# Altium schematic sheet lv_signal_temp.SchDoc
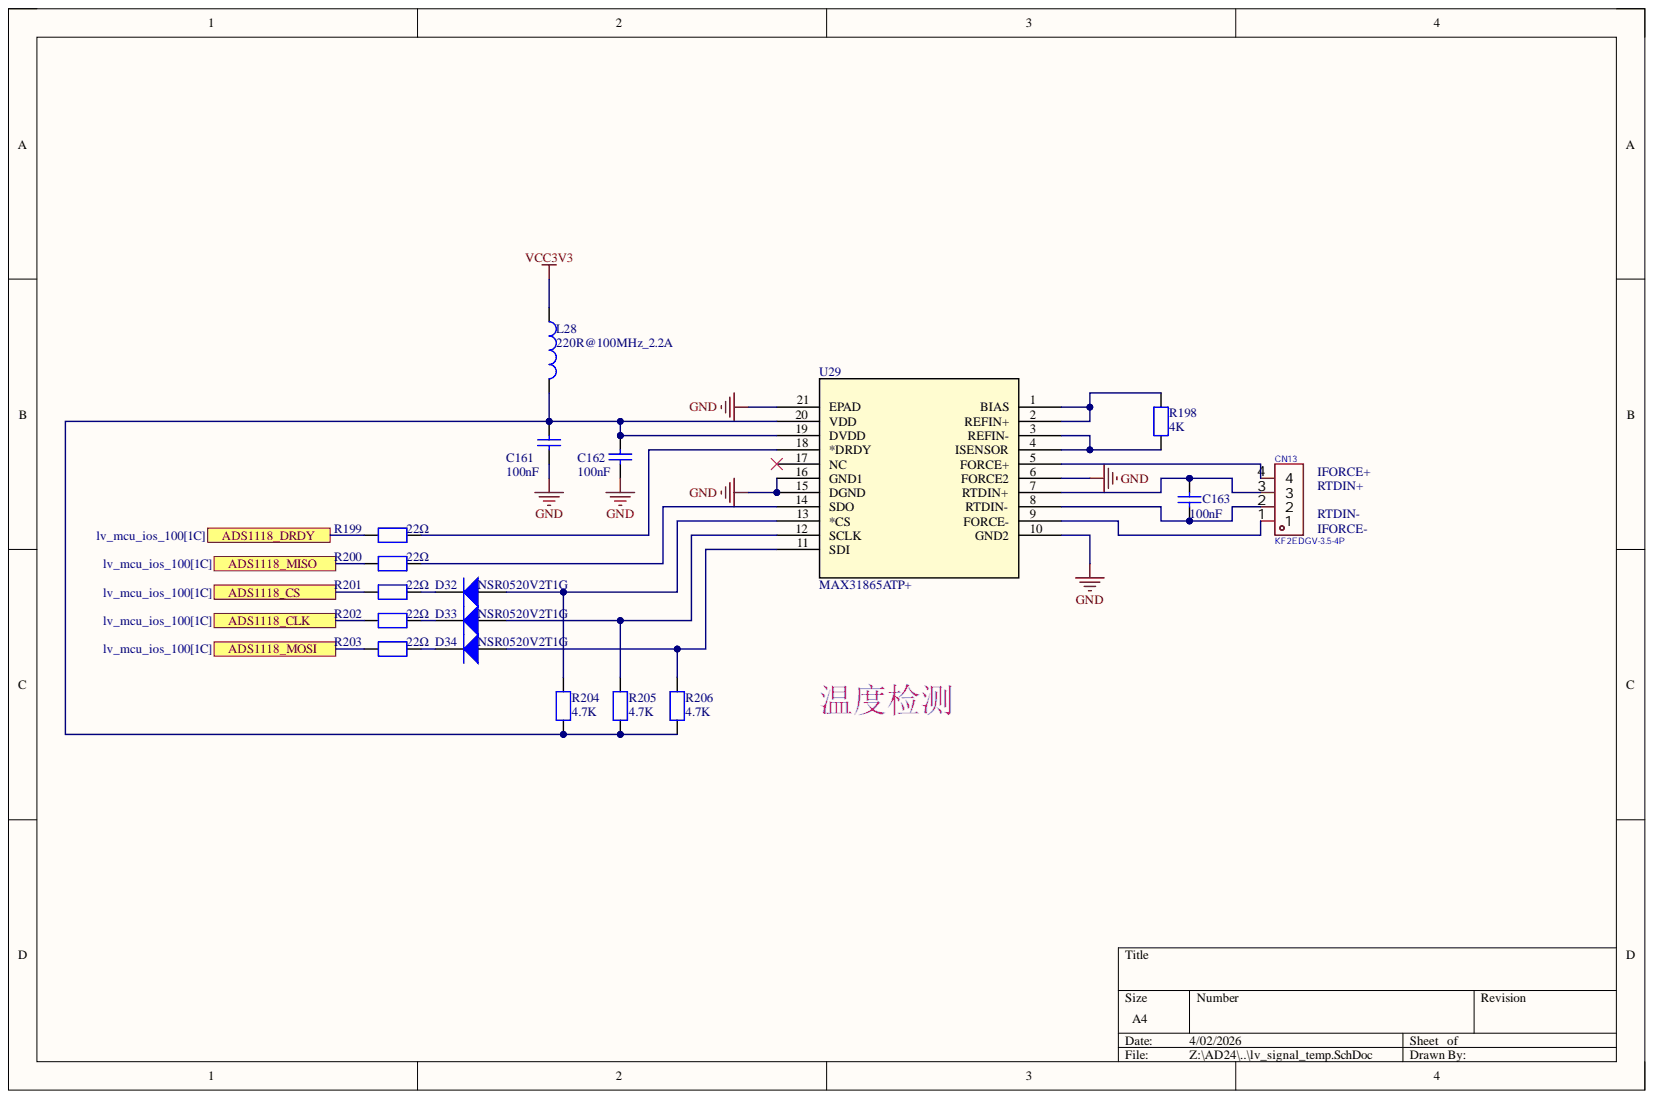
[diagram p0: lv_signal_temp.SchDoc]

## Parts
C161  100nF  [C0402]
C162  100nF  [C0402]
C163  100nF  [C0402]
CN13  KF2EDGV-3.5-4P  [CONN-TH_4P-P3.50_KF2EDGV-3.5-4P]
D32  NSR0520V2T1G  [SOD-523F_L1.2-W0.8-LS1.7-RD]
D33  NSR0520V2T1G  [SOD-523F_L1.2-W0.8-LS1.7-RD]
D34  NSR0520V2T1G  [SOD-523F_L1.2-W0.8-LS1.7-RD]
L28  220R@100MHz_2.2A  [L0603]
R198  4K  [0805]
R199  22Ω  [R0402]
R200  22Ω  [R0402]
R201  22Ω  [R0402]
R202  22Ω  [R0402]
R203  22Ω  [R0402]
R204  4.7K  [R0402]
R205  4.7K  [R0402]
R206  4.7K  [R0402]
U29  MAX31865ATP+  [21-0140_T2055+5_MXM]

## Ports
ADS1118_CLK, ADS1118_CS, ADS1118_DRDY, ADS1118_MISO, ADS1118_MOSI

## Nets
GND: C161.2 C162.2 U29.6(FORCE2) U29.10(GND2) U29.15(DGND) U29.16(GND1) U29.21(EPAD)
VCC3V3: L28.2
ADS1118_CLK: R202.2 ; port ADS1118_CLK
ADS1118_CS: R201.2 ; port ADS1118_CS
ADS1118_DRDY: R199.2 ; port ADS1118_DRDY
ADS1118_MISO: R200.2 ; port ADS1118_MISO
ADS1118_MOSI: R203.2 ; port ADS1118_MOSI
NetC161_1: C161.1 C162.1 L28.1 R204.2 R205.2 R206.2 U29.19(DVDD) U29.20(VDD)
NetC163_1: C163.1 CN13.3 U29.7(RTDIN+)
NetC163_2: C163.2 CN13.2 U29.8(RTDIN-)
NetCN13_1: CN13.1 U29.9(FORCE-)
NetCN13_4: CN13.4 U29.5(FORCE+)
NetD32_1: D32.1 R201.1
NetD32_2: D32.2 R204.1 U29.13(*CS)
NetD33_1: D33.1 R202.1
NetD33_2: D33.2 R205.1 U29.12(SCLK)
NetD34_1: D34.1 R203.1
NetD34_2: D34.2 R206.1 U29.11(SDI)
NetR198_1: R198.1 U29.1(BIAS) U29.2(REFIN+)
NetR198_2: R198.2 U29.3(REFIN-) U29.4(ISENSOR)
NetR199_1: R199.1 U29.18(*DRDY)
NetR200_1: R200.1 U29.14(SDO)

Not connected: U29 17(NC)

## Library data of the parts
C161 C162 = Capacitor: 50V, 5%, X7R; Manufacturer: muRata; Manufacturer_Part_Number: GRM155R71H104KE14D; Component Kind: Standard; ComponentLink1Description: Datasheet; ComponentLink1URL: http://tunmcdsvn.tun.st.com/Component_Datasheet_Library/Trunk/Capacitors/Yageo_Capacitor_NP0_16V-to-50V; Library Name: HiPin_Library_AD.IntLib
C163 = Capacitor_1: 50V, X7R, 10%; Manufacturer: muRata; Manufacturer_Part_Number: GRM188R71H104KA93D; Component Kind: Standard; ComponentLink1Description: Yageo_Capacitor_X5R-series.pdf; ComponentLink1URL: http://tunmcdsvn.tun.st.com/Component_Datasheet_Library/Trunk/Capacitors/Yageo_Multilayer_capacitor_X5R_series_100pF_to_100uF; Library Name: HiPin_Library_AD.IntLib
CN13 = KF2EDGV-3.5-4P: 3.5mm , 4Pin; Manufacturer: KEFA(科发); Add into BOM: yes; Connector Type: 板端/插座-闭口; Current Rating (Max): 10A; Number of PINs Per Row: 4; Structure: 1x4P; Voltage Rating (Max): 300V; Color: 绿色; Component Kind: Standard; Connection Type: -; Contact Material: 铜合金; Contact Plating: 锡; Convert to PCB: yes; Datasheet: https://atta.szlcsc.com/upload/public/pdf/source/20230331/973DE48A1FC0C5DCFB383F3EF6D70D72.pdf; Device: KF2EDGV-3.5-4P; fixed form: -; JLCPCB Part Class: Extended Part; LCSC Part Name: 1x4P 3.5mm 排数:1 每排P数:4; Library Name: HiPin_Library_AD.IntLib; Manufacturer Part: KF2EDGV-3.5-4P; Mounting Style: 直针; Number of Pins: 4P; Number of Rows: 1; Operating Temperature Range: -40℃~+105℃; Outlet Direction (Vertical Basis): -; PCB3D: CONN-TH_4P-P3.50_KF2EDGV-3.5-4P; Pitch: 3.5mm; Soldering Temperature(Max): -; Supplier: LCSC; Supplier Footprint: 插件,P=3.5mm; Supplier Part: C441323; Symbol: KF2EDGV-3.5-4P; Wire Gauge - AWG: -; Wire Gauge - mm2: -
D32-D34 = 1N4148: Component Kind: Standard; Library Name: HiPin_Library_AD.IntLib
L28 = MCD-00047: SMD Chip ferrite bead, 220R@100MHz +/-25%,2.2A, 50mohm; Manufacturer: Sunlord; Manufacturer_Part_Number: UPZ1608U221-2R2TF; Database Table Name: MCD_PARTS$; Component Kind: Standard; ComponentLink1Description: Datasheet; ComponentLink1URL: http://tunmcdsvn.tun.st.com/Component_Datasheet_Library/Trunk/Inductors/TaiTech_Bead_FCM1608_series; Internal_Part_Number: MCD-00047; Library Name: MCD_PARTS_database.DbLib
R198 = RES: 0.1%, 25ppm; Component Kind: Standard; Library Name: HiPin_Library_AD.IntLib
R199-R206 = RES: 1%; Component Kind: Standard; Library Name: HiPin_Library_AD.IntLib
U29 = MAX31865ATP+: RTD-to-Digital Converter; Manufacturer_Name: Analog Devices Inc; Component Kind: Standard; Library Name: HiPin_Library_AD.IntLib; Manufacturer_Part_Number: MAX31865ATP+; RefDes: RefDes; Type: Type; Copyright: Copyright (C) 2025 Ultra Librarian. All rights reserved.
